# Altium schematic sheet 04-ASIC-Chip.SchDoc(ASIC-Chip)
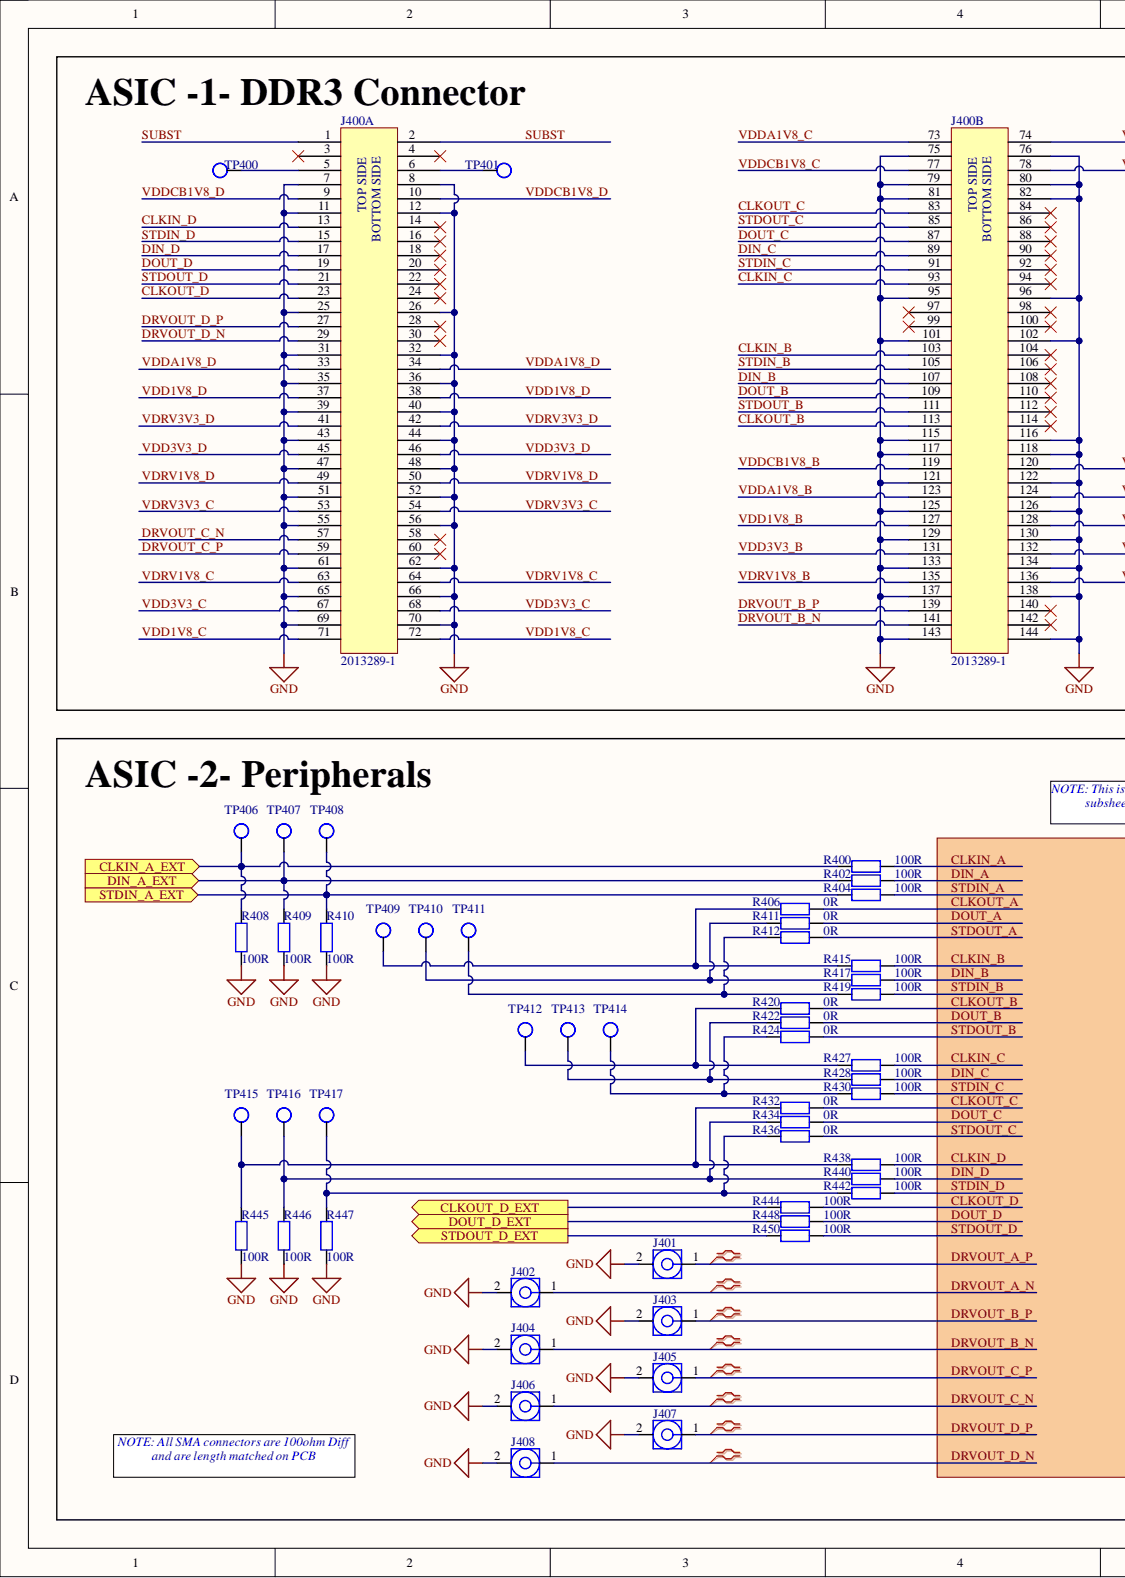
[diagram p0: 04-ASIC-Chip.SchDoc, left]
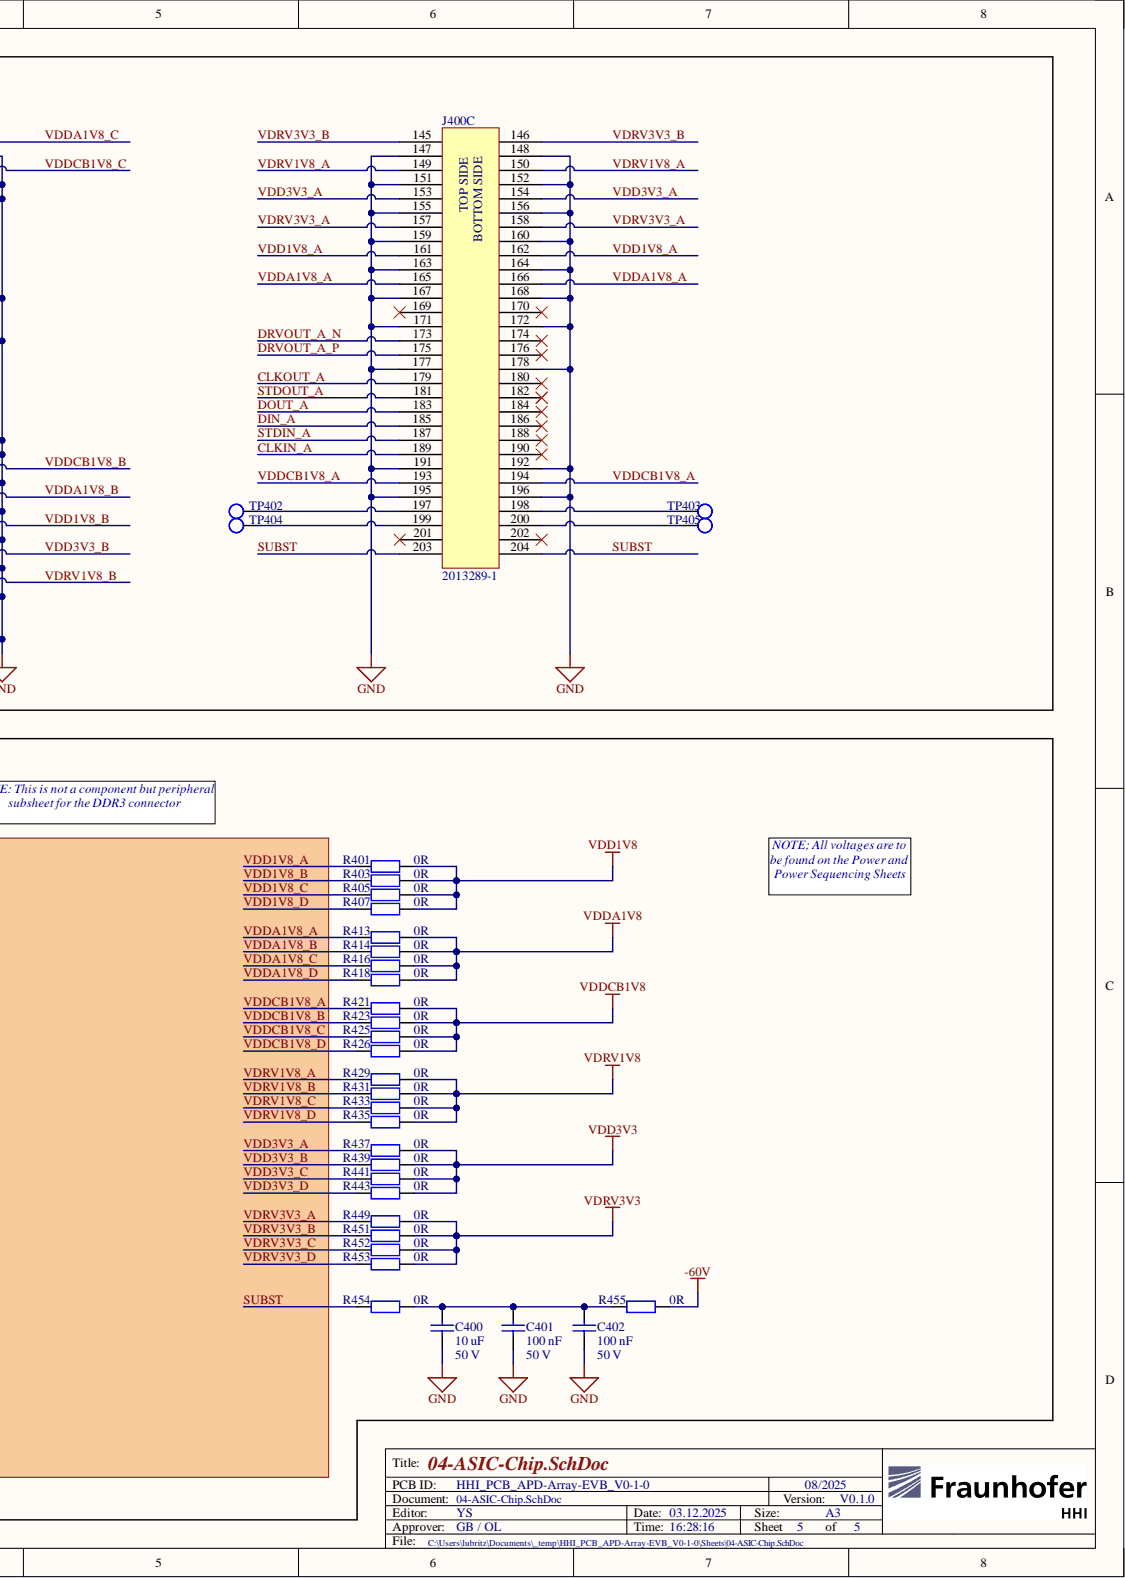
[diagram p1: 04-ASIC-Chip.SchDoc, right]

## Parts
C400  10 uF  Voltage: 50 V  [CAP_CAPC2012_0805_N]
C401  100 nF  Voltage: 50 V  [CAP_CAPC1608_0603_N]
C402  100 nF  Voltage: 50 V  [CAP_CAPC1608_0603_N]
J400  2013289-1  [CON_FEMALE_2013289-1]  (parts A,B,C)
J401  CONSMA020.062-G  [CON_FEMALE_CONSMA020.062-G]  (value not drawn)
J402  CONSMA020.062-G  [CON_FEMALE_CONSMA020.062-G]  (value not drawn)
J403  CONSMA020.062-G  [CON_FEMALE_CONSMA020.062-G]  (value not drawn)
J404  CONSMA020.062-G  [CON_FEMALE_CONSMA020.062-G]  (value not drawn)
J405  CONSMA020.062-G  [CON_FEMALE_CONSMA020.062-G]  (value not drawn)
J406  CONSMA020.062-G  [CON_FEMALE_CONSMA020.062-G]  (value not drawn)
J407  CONSMA020.062-G  [CON_FEMALE_CONSMA020.062-G]  (value not drawn)
J408  CONSMA020.062-G  [CON_FEMALE_CONSMA020.062-G]  (value not drawn)
R400  100R  [RES_RESC1608_0603_N]
R401  0R  [RES_RESC1608_0603_N]
R402  100R  [RES_RESC1608_0603_N]
R403  0R  [RES_RESC1608_0603_N]
R404  100R  [RES_RESC1608_0603_N]
R405  0R  [RES_RESC1608_0603_N]
R406  0R  [RES_RESC1608_0603_N]
R407  0R  [RES_RESC1608_0603_N]
R408  100R  [RES_RESC1608_0603_N]
R409  100R  [RES_RESC1608_0603_N]
R410  100R  [RES_RESC1608_0603_N]
R411  0R  [RES_RESC1608_0603_N]
R412  0R  [RES_RESC1608_0603_N]
R413  0R  [RES_RESC1608_0603_N]
R414  0R  [RES_RESC1608_0603_N]
R415  100R  [RES_RESC1608_0603_N]
R416  0R  [RES_RESC1608_0603_N]
R417  100R  [RES_RESC1608_0603_N]
R418  0R  [RES_RESC1608_0603_N]
R419  100R  [RES_RESC1608_0603_N]
R420  0R  [RES_RESC1608_0603_N]
R421  0R  [RES_RESC1608_0603_N]
R422  0R  [RES_RESC1608_0603_N]
R423  0R  [RES_RESC1608_0603_N]
R424  0R  [RES_RESC1608_0603_N]
R425  0R  [RES_RESC1608_0603_N]
R426  0R  [RES_RESC1608_0603_N]
R427  100R  [RES_RESC1608_0603_N]
R428  100R  [RES_RESC1608_0603_N]
R429  0R  [RES_RESC1608_0603_N]
R430  100R  [RES_RESC1608_0603_N]
R431  0R  [RES_RESC1608_0603_N]
R432  0R  [RES_RESC1608_0603_N]
R433  0R  [RES_RESC1608_0603_N]
R434  0R  [RES_RESC1608_0603_N]
R435  0R  [RES_RESC1608_0603_N]
R436  0R  [RES_RESC1608_0603_N]
R437  0R  [RES_RESC1608_0603_N]
R438  100R  [RES_RESC1608_0603_N]
R439  0R  [RES_RESC1608_0603_N]
R440  100R  [RES_RESC1608_0603_N]
R441  0R  [RES_RESC1608_0603_N]
R442  100R  [RES_RESC1608_0603_N]
R443  0R  [RES_RESC1608_0603_N]
R444  100R  [RES_RESC1608_0603_N]
R445  100R  [RES_RESC1608_0603_N]
R446  100R  [RES_RESC1608_0603_N]
R447  100R  [RES_RESC1608_0603_N]
R448  100R  [RES_RESC1608_0603_N]
R449  0R  [RES_RESC1608_0603_N]
R450  100R  [RES_RESC1608_0603_N]
R451  0R  [RES_RESC1608_0603_N]
R452  0R  [RES_RESC1608_0603_N]
R453  0R  [RES_RESC1608_0603_N]
R454  0R  [RES_RESC1608_0603_N]
R455  0R  [RES_RESC1608_0603_N]
TP400  0.6 mm / 1 mm  [MISC_TP_THT_RD_0,6MM_1MM]  (value not drawn)  (no BOM)
TP401  0.6 mm / 1 mm  [MISC_TP_THT_RD_0,6MM_1MM]  (value not drawn)  (no BOM)
TP402  0.6 mm / 1 mm  [MISC_TP_THT_RD_0,6MM_1MM]  (value not drawn)  (no BOM)
TP403  0.6 mm / 1 mm  [MISC_TP_THT_RD_0,6MM_1MM]  (value not drawn)  (no BOM)
TP404  0.6 mm / 1 mm  [MISC_TP_THT_RD_0,6MM_1MM]  (value not drawn)  (no BOM)
TP405  0.6 mm / 1 mm  [MISC_TP_THT_RD_0,6MM_1MM]  (value not drawn)  (no BOM)
TP406  0,8 mm / 1,4 mm  [MISC_TP_THT_RD_0,8MM_1,4MM]  (value not drawn)  (no BOM)
TP407  0,8 mm / 1,4 mm  [MISC_TP_THT_RD_0,8MM_1,4MM]  (value not drawn)  (no BOM)
TP408  0,8 mm / 1,4 mm  [MISC_TP_THT_RD_0,8MM_1,4MM]  (value not drawn)  (no BOM)
TP409  0,8 mm / 1,4 mm  [MISC_TP_THT_RD_0,8MM_1,4MM]  (value not drawn)  (no BOM)
TP410  0,8 mm / 1,4 mm  [MISC_TP_THT_RD_0,8MM_1,4MM]  (value not drawn)  (no BOM)
TP411  0,8 mm / 1,4 mm  [MISC_TP_THT_RD_0,8MM_1,4MM]  (value not drawn)  (no BOM)
TP412  0,8 mm / 1,4 mm  [MISC_TP_THT_RD_0,8MM_1,4MM]  (value not drawn)  (no BOM)
TP413  0,8 mm / 1,4 mm  [MISC_TP_THT_RD_0,8MM_1,4MM]  (value not drawn)  (no BOM)
TP414  0,8 mm / 1,4 mm  [MISC_TP_THT_RD_0,8MM_1,4MM]  (value not drawn)  (no BOM)
TP415  0,8 mm / 1,4 mm  [MISC_TP_THT_RD_0,8MM_1,4MM]  (value not drawn)  (no BOM)
TP416  0,8 mm / 1,4 mm  [MISC_TP_THT_RD_0,8MM_1,4MM]  (value not drawn)  (no BOM)
TP417  0,8 mm / 1,4 mm  [MISC_TP_THT_RD_0,8MM_1,4MM]  (value not drawn)  (no BOM)

## Ports
CLKIN_A_EXT, CLKOUT_D_EXT, DIN_A_EXT, DOUT_D_EXT, STDIN_A_EXT, STDOUT_D_EXT

## Nets
-60V: R455.2
GND: C400.2 C401.2 C402.2 J400.7 J400.8 J400.11 J400.12 J400.25 J400.26 J400.31 J400.32 J400.35 J400.36 J400.39 J400.40 J400.43 J400.44 J400.47 J400.48 J400.51 J400.52 J400.55 J400.56 J400.61 J400.62 J400.65 J400.66 J400.69 J400.70 J400.75 J400.76 J400.79 J400.80 J400.81 J400.82 J400.95 J400.96 J400.101 J400.102 J400.115 J400.116 J400.117 J400.118 J400.121 J400.122 J400.125 J400.126 J400.129 J400.130 J400.133 J400.134 J400.137 J400.138 J400.143 J400.144 J400.147 J400.148 J400.151 J400.152 J400.155 J400.156 J400.159 J400.160 J400.163 J400.164 J400.167 J400.168 J400.171 J400.172 J400.177 J400.178 J400.191 J400.192 J400.195 J400.196 J401.2 J402.2 J403.2 J404.2 J405.2 J406.2 J407.2 J408.2 R408.2 R409.1 R410.1 R445.2 R446.1 R447.1
VDD1V8: R401.2 R403.2 R405.2 R407.2
VDD3V3: R437.2 R439.2 R441.2 R443.2
VDDA1V8: R413.2 R414.2 R416.2 R418.2
VDDCB1V8: R421.2 R423.2 R425.2 R426.2
VDRV1V8: R429.2 R431.2 R433.2 R435.2
VDRV3V3: R449.2 R451.2 R452.2 R453.2
CLKIN_A: J400.189 R400.2
CLKIN_A_EXT: R400.1 R408.1 TP406.1 ; port CLKIN_A_EXT
CLKIN_B: J400.103 R415.2
CLKIN_C: J400.93 R427.2
CLKIN_D: J400.13 R438.2
CLKOUT_A: J400.179 R406.2
CLKOUT_B: J400.113 R420.2
CLKOUT_C: J400.83 R432.2
CLKOUT_D: J400.23 R444.2
CLKOUT_D_EXT: R444.1 ; port CLKOUT_D_EXT
DIN_A: J400.185 R402.2
DIN_A_EXT: R402.1 R409.2 TP407.1 ; port DIN_A_EXT
DIN_B: J400.107 R417.2
DIN_C: J400.89 R428.2
DIN_D: J400.17 R440.2
DOUT_A: J400.183 R411.2
DOUT_B: J400.109 R422.2
DOUT_C: J400.87 R434.2
DOUT_D: J400.19 R448.2
DOUT_D_EXT: R448.1 ; port DOUT_D_EXT
DRVOUT_A_N: J400.173 J402.1
DRVOUT_A_P: J400.175 J401.1
DRVOUT_B_N: J400.141 J404.1
DRVOUT_B_P: J400.139 J403.1
DRVOUT_C_N: J400.57 J406.1
DRVOUT_C_P: J400.59 J405.1
DRVOUT_D_N: J400.29 J408.1
DRVOUT_D_P: J400.27 J407.1
STDIN_A: J400.187 R404.2
STDIN_A_EXT: R404.1 R410.2 TP408.1 ; port STDIN_A_EXT
STDIN_B: J400.105 R419.2
STDIN_C: J400.91 R430.2
STDIN_D: J400.15 R442.2
STDOUT_A: J400.181 R412.2
STDOUT_B: J400.111 R424.2
STDOUT_C: J400.85 R436.2
STDOUT_D: J400.21 R450.2
STDOUT_D_EXT: R450.1 ; port STDOUT_D_EXT
SUBST: J400.1 J400.2 J400.203 J400.204 R454.1
VDD1V8_A: J400.161 J400.162 R401.1
VDD1V8_B: J400.127 J400.128 R403.1
VDD1V8_C: J400.71 J400.72 R405.1
VDD1V8_D: J400.37 J400.38 R407.1
VDD3V3_A: J400.153 J400.154 R437.1
VDD3V3_B: J400.131 J400.132 R439.1
VDD3V3_C: J400.67 J400.68 R441.1
VDD3V3_D: J400.45 J400.46 R443.1
VDDA1V8_A: J400.165 J400.166 R413.1
VDDA1V8_B: J400.123 J400.124 R414.1
VDDA1V8_C: J400.73 J400.74 R416.1
VDDA1V8_D: J400.33 J400.34 R418.1
VDDCB1V8_A: J400.193 J400.194 R421.1
VDDCB1V8_B: J400.119 J400.120 R423.1
VDDCB1V8_C: J400.77 J400.78 R425.1
VDDCB1V8_D: J400.9 J400.10 R426.1
VDRV1V8_A: J400.149 J400.150 R429.1
VDRV1V8_B: J400.135 J400.136 R431.1
VDRV1V8_C: J400.63 J400.64 R433.1
VDRV1V8_D: J400.49 J400.50 R435.1
VDRV3V3_A: J400.157 J400.158 R449.1
VDRV3V3_B: J400.145 J400.146 R451.1
VDRV3V3_C: J400.53 J400.54 R452.1
VDRV3V3_D: J400.41 J400.42 R453.1
NetC400_1: C400.1 C401.1 C402.1 R454.2 R455.1
NetJ400_3: J400.3
NetJ400_4: J400.4
NetJ400_5: J400.5 TP400.1
NetJ400_6: J400.6 TP401.1
NetJ400_14: J400.14
NetJ400_16: J400.16
NetJ400_18: J400.18
NetJ400_20: J400.20
NetJ400_22: J400.22
NetJ400_24: J400.24
NetJ400_28: J400.28
NetJ400_30: J400.30
NetJ400_58: J400.58
NetJ400_60: J400.60
NetJ400_84: J400.84
NetJ400_86: J400.86
NetJ400_88: J400.88
NetJ400_90: J400.90
NetJ400_92: J400.92
NetJ400_94: J400.94
NetJ400_97: J400.97
NetJ400_98: J400.98
NetJ400_99: J400.99
NetJ400_100: J400.100
NetJ400_104: J400.104
NetJ400_106: J400.106
NetJ400_108: J400.108
NetJ400_110: J400.110
NetJ400_112: J400.112
NetJ400_114: J400.114
NetJ400_140: J400.140
NetJ400_142: J400.142
NetJ400_169: J400.169
NetJ400_170: J400.170
NetJ400_174: J400.174
NetJ400_176: J400.176
NetJ400_180: J400.180
NetJ400_182: J400.182
NetJ400_184: J400.184
NetJ400_186: J400.186
NetJ400_188: J400.188
NetJ400_190: J400.190
NetJ400_197: J400.197 TP402.1
NetJ400_198: J400.198 TP403.1
NetJ400_199: J400.199 TP404.1
NetJ400_200: J400.200 TP405.1
NetJ400_201: J400.201
NetJ400_202: J400.202
NetR406_1: R406.1 R415.1 TP409.1
NetR411_1: R411.1 R417.1 TP410.1
NetR412_1: R412.1 R419.1 TP411.1
NetR420_1: R420.1 R427.1 TP412.1
NetR422_1: R422.1 R428.1 TP413.1
NetR424_1: R424.1 R430.1 TP414.1
NetR432_1: R432.1 R438.1 R445.1 TP415.1
NetR434_1: R434.1 R440.1 R446.2 TP416.1
NetR436_1: R436.1 R442.1 R447.2 TP417.1

## Library data of the parts
C400 = CAP0067: CAP / 10 uF / 0805 / 50 V / X5R / 10%; Mfr: Murata; MfrNo: GRM21BR61H106KE43L; Database Table Name: capacitor$; Dis: Mouser; DisNo: 81-GRM21BR61H106KE3L; Editor: OL; ProvidedBy: EMS; Type: MLCC; Component Kind: Standard; DesignItemID: CAP0067; Dielectric: X5R; ESR: -; Mfr-Link: https://www.murata.com/en-eu/products/productdetail?partno=GRM21BR61H106KE43%23; Package: 0805; Pins: 2; Status: Done; TempHigh: 85 °C; TempLow: -55 °C; Tolerance: 10%; Value: 10 uF; Library Name: Altium-Lib-HHI.DbLib
C401 C402 = CAP0001: CAP / 100 nF / 0603 / 50 V / X7R / 10%; Mfr: Würth Elektronik; MfrNo: 885012206095; Database Table Name: capacitor$; Dis: Mouser; DisNo: 710-885012206095; Editor: OL; ProvidedBy: EMS; Type: MLCC; Component Kind: Standard; DesignItemID: CAP0001; Dielectric: X7R; ESR: -; Mfr-Link: https://www.we-online.com/de/components/products/WCAP-CSGP-50VDC; Package: 0603; Pins: 2; Status: Done; TempHigh: 125 °C; TempLow: -55 °C; Tolerance: 10%; Value: 100 nF; Library Name: Altium-Lib-HHI.DbLib
J400 = CON0022: CON / 2 x 102 / female / DDR3 SDRAM / 2013289-1; Mfr: TE Connectivity; Assembly: SMD; Current: 0.5 A; Database Table Name: connectors$; Dis: Mouser; DisNo: 571-2013289-1; Editor: OL; Pins per Row: 102; Pitch: 0,6 mm; Plating: Gold; ProvidedBy: EMS; Rows: 2; Voltage: 1.5V; Component Kind: Standard; DesignItemID: CON0022; Gender: female; Mfr-Link: https://www.te.com/de/product-2-2013289-1.html; MfrNo: 2013289-1; Pins: 204; Status: Done; TempHigh: 85 °C; TempLow: -55 °C; Type: DDR3 SDRAM; Value: 2013289-1; Library Name: Altium-Lib-HHI.DbLib
J401-J408 = CON0023: CON / - x - / female / SMA / CONSMA020.062-G; Mfr: TE Connectivity; Assembly: SMD; Database Table Name: connectors$; Dis: Mouser; DisNo: 712-CONSMA020.062-G; Editor: YS; Plating: Gold; ProvidedBy: HHI; Voltage: 500 V(AC); Component Kind: Standard; Current: -; DesignItemID: CON0023; Gender: female; Library Name: Altium-Lib-HHI.DbLib; Mfr-Link: https://www.te.com/de/product-L9000279-01.html; MfrNo: CONSMA020.062-G; Pins: 5; Pins per Row: -; Pitch: -; Rows: -; Status: Done; TempHigh: 165 °C; TempLow: -65 °C; Type: SMA; Value: CONSMA020.062-G
R400 R402 R404 R408-R410 R415 R417 R419 R427 R428 R430 R438 R440 R442 R444-R448 R450 = RES0009: RES / 100R / 0603 / 1% / 75 V / 100 mW; Mfr: YAGEO; MfrNo: RC0603FR-07100RL; Database Table Name: resistor$; Dis: Mouser; DisNo: 603-RC0603FR-07100RL; Editor: OL; Power: 100 mW; ProvidedBy: EMS; Voltage: 75 V; Component Kind: Standard; DesignItemID: RES0009; Mfr-Link: https://www.yageo.com/upload/media/product/productsearch/datasheet/rchip/PYu-RC_Group_51_RoHS_L_12.pdf; Package: 0603; Pins: 2; Status: Done; TempHigh: 155 °C; TempLow: -55 °C; Tolerance: 1%; Type: resistor; Value: 100R; Library Name: Altium-Lib-HHI.DbLib
R401 R403 R405-R407 R411-R414 R416 R418 R420-R426 R429 R431-R437 R439 R441 R443 R449 R451-R455 = RES0072: RES / 0R / 0603 / < 20mR / 75 V / 210 mW; Mfr: Vishay; MfrNo: MCT0603MZ0000ZP500; Database Table Name: resistor$; Dis: Mouser; DisNo: 594-MCT0603MZ0000ZP5; Editor: OL; Power: 210 mW; ProvidedBy: EMS; Tolerance: < 20mR; Voltage: 75 V; Component Kind: Standard; DesignItemID: RES0072; Mfr-Link: https://www.vishay.com/en/product/28952/; Package: 0603; Pins: 2; Status: Done; TempHigh: 175 °C; TempLow: -55 °C; Type: jumper; Value: 0R; Library Name: Altium-Lib-HHI.DbLib
TP400-TP405 = MISC0004: MISC / test-point / 0.6 mm / 1 mm / round / THT; Database Table Name: misc$; Editor: OL; AdditionalDescription: round / THT; DesignItemID: MISC0004; Dis: -; DisNo: -; Frequency: -; MaxCurrent: -; Mfr: -; Mfr-Link: -; MfrNo: -; Package: -; Pins: -; ProvidedBy: -; Status: Done; TempHigh: -; TempLow: -; Type: test-point; Value: 0.6 mm / 1 mm; Voltage: -; Library Name: Altium-Lib-HHI.DbLib
TP406-TP417 = MISC0008: MISC / test-point / 0,8 mm / 1,4 mm / round / THT; Database Table Name: misc$; Editor: OL; AdditionalDescription: round / THT; DesignItemID: MISC0008; Dis: -; DisNo: -; Frequency: -; MaxCurrent: -; Mfr: -; Mfr-Link: -; MfrNo: -; Package: -; Pins: -; ProvidedBy: -; Status: Done; TempHigh: -; TempLow: -; Type: test-point; Value: 0,8 mm / 1,4 mm; Voltage: -; Library Name: Altium-Lib-HHI.DbLib
